# Altium schematic sheet 3xOuts.SchDoc
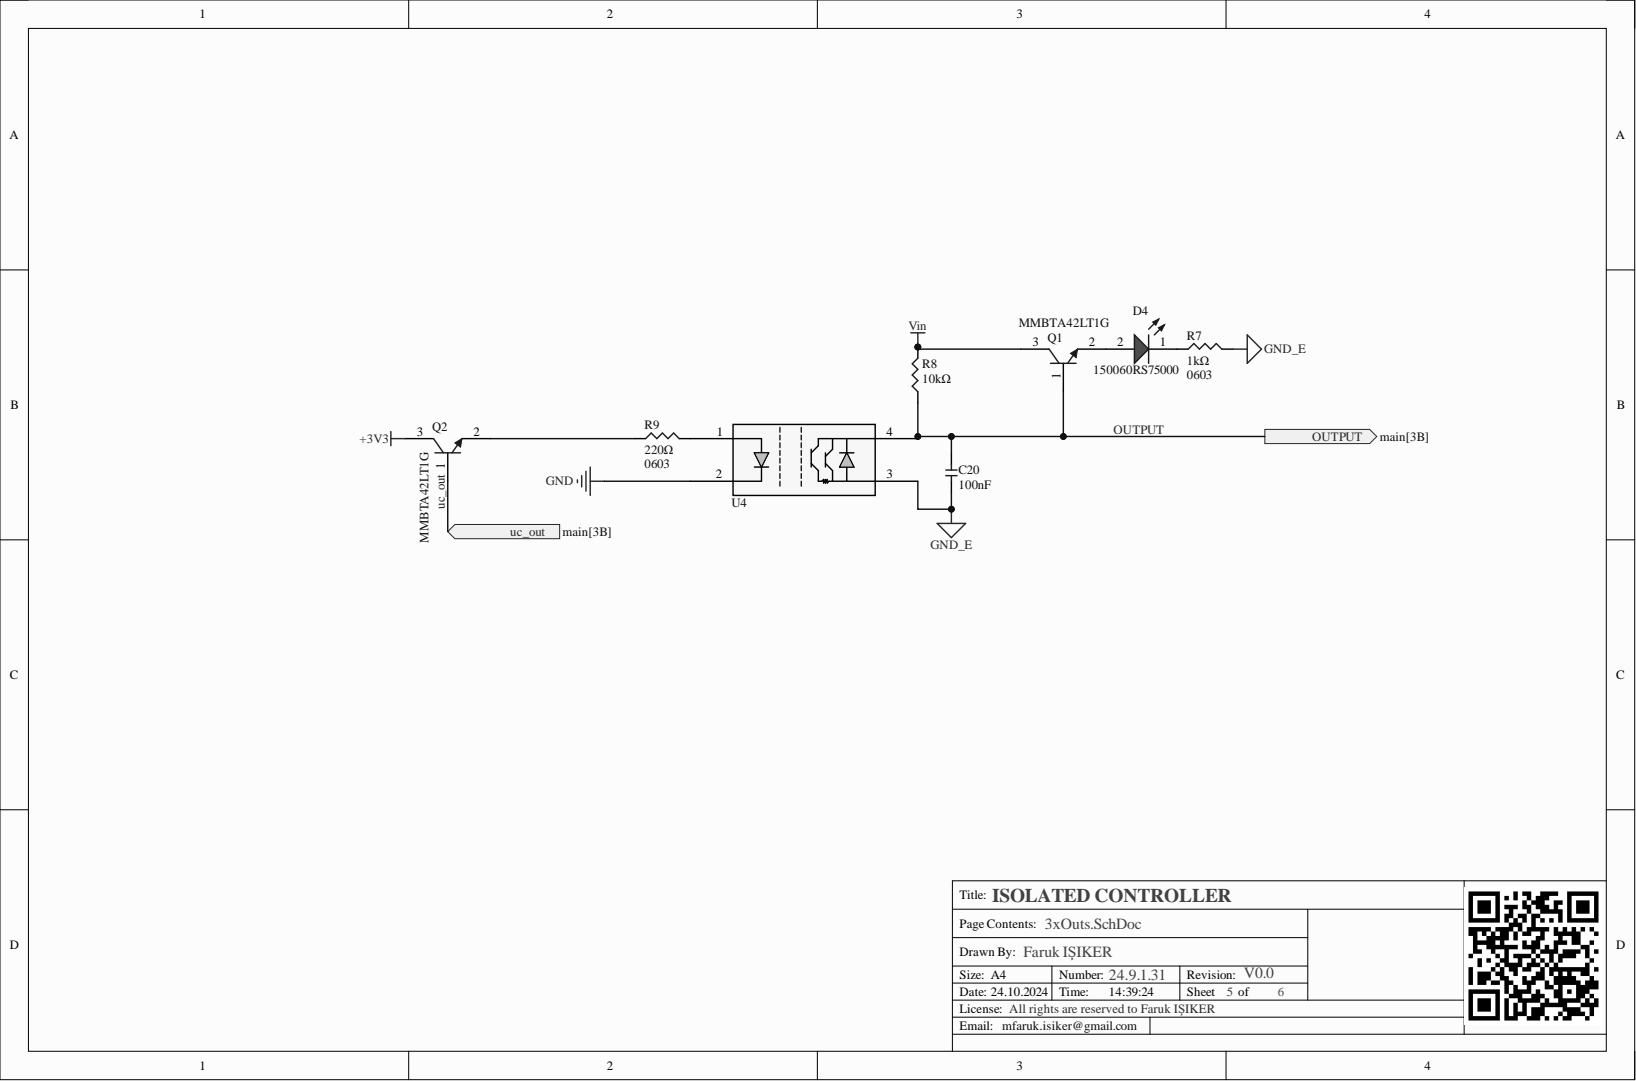
[diagram p0: 3xOuts.SchDoc]

## Parts
C20  value 100nF  [0603_cap]  (hidden comment: 0603_100nF)
D4  150060RS75000  Case Code (Imperial): 0603  [WL-SMCW_0603_150060xx75000]
Q1  MMBTA42LT1G  [ONSC-SOT-23-3-318-08_V]
Q2  MMBTA42LT1G  [ONSC-SOT-23-3-318-08_V]
R7  value 1kΩ  Package: 0603  [0603-smd]  (hidden comment: 0603_1K)
R8  value 10kΩ  [0603-smd]  (hidden comment: 0603_10K)
R9  0603_220R  value 220Ω  Package: 0603  [0603-smd]
U4  LTV-352T  [IC4_LTV-352T_LTO-M]  (value not drawn)

## Ports
OUTPUT, uc_out

## Nets
+3V3: Q2.3
GND: U4.2
GND_E: C20.1 R7.2 U4.3
Vin: Q1.3 R8.2
OUTPUT: C20.2 Q1.1 R8.1 U4.4 ; port OUTPUT
uc_out: Q2.1 ; port uc_out
NetD4_1: D4.1 R7.1
NetD4_2: D4.2 Q1.2
NetQ2_2: Q2.2 R9.1
NetR9_2: R9.2 U4.1

## Library data of the parts
C20 = 0603_100nF: Volt: V; Component Kind: Standard; Library Name: generic.SchLib; Package: 0603
D4 = CMP-1426-00001-3: NexarPartId: 29717776; Color: Red; Dominant Wavelength: 625nm; Forward Current: 100mA; Forward Voltage: 2V; Lens Style: Clear, Rectangular; Luminous Intensity: 250mcd; Max Junction Temperature (Tj): 95°C; Max Reverse Voltage (DC): 5V; Peak Wavelength: 630nm; Power Dissipation: 72mW; Test Current: 20mA; Viewing Angle: 140°; Case Code (Metric): 1608; Case/Package: 0603; Component Kind: Standard; Height: 700µm; Length: 1.6mm; Library Name: Altium Content Vault; Max Operating Temperature: 85°C; Min Operating Temperature: -40°C; Mount: Surface Mount; Packaging: Tape and Reel; REACH SVHC: Unknown; Reverse Voltage: 5V; RoHS: Compliant; Wavelength: 625nm; Width: 800µm
Q1 Q2 = CMP-1048-00662-1: High Voltage Transistor, NPN Silicon, 3-Pin SOT-23, Pb-Free, Tape and Reel; Manufacturer: On Semiconductor; fT Min (MHz): 50; IC Continuous (A): 0.5; Component Kind: Standard; ComponentLink1Description: Manufacturer URL; ComponentLink1URL: http://www.onsemi.com/; ComponentLink2Description: Datasheet; ComponentLink2URL: http://www.onsemi.cn/pub_link/Collateral/MMBTA42LT1-D.PDF; ComponentLink3Description: Package Specification; ComponentLink3URL: http://www.onsemi.cn/pub_link/Collateral/318-08.PDF; DatasheetVersion: Rev. 12; Library Name: Altium Content Vault; Mounting Technology: Surface Mount; PackageDescription: 3-Pin Small Outline Transistor, Body 2.92 x 1.3 mm, Pitch 0.95 mm; PackageReference: SOT-23-3-318-08; PackageVersion: Rev. AP, 11/2009; Packing: Tape and Reel; PartNumber: MMBTA42LT1G; Polarity: NPN; RoHS: TRUE
R7 = 0603_1K: Power: W; Component Kind: Standard; Library Name: generic.SchLib
R8 = 0603_10K: Power: W; Component Kind: Standard; Library Name: generic.SchLib; Package: 0603
R9 = 0603_220R: Power: W; Component Kind: Standard; Library Name: generic.SchLib
U4 = LTV-352T: LTV Series Single Channel 300V 3750 Vrms Transistor Output Optocoupler SMT DIP-4; Manufacturer: Vishay Lite-On; Mfr_Name: LITE-ON; Category: Optocouplers; Component Kind: Standard; ComponentLink1Description: https://datasheet.ciiva.com/11772/0900766b80de6aef-11772096.pdf?src-supplier=Rs; ComponentLink1URL: https://datasheet.ciiva.com/11772/0900766b80de6aef-11772096.pdf?src-supplier=Rs; ComponentLink2Description: https://datasheet.ciiva.com/2086/ltv-352t-2086258.pdf?src-supplier=Digikey; ComponentLink2URL: https://datasheet.ciiva.com/2086/ltv-352t-2086258.pdf?src-supplier=Digikey; ComponentLink3Description: https://datasheet.ciiva.com/19471/1260710-19471302.pdf?src-supplier=Jameco; ComponentLink3URL: https://datasheet.ciiva.com/19471/1260710-19471302.pdf?src-supplier=Jameco; ComponentLink4Description: https://datasheet.ciiva.com/15118/getdatasheetpartid-145582-15118811.pdf?src-supplier=Verical; ComponentLink4URL: https://datasheet.ciiva.com/15118/getdatasheetpartid-145582-15118811.pdf?src-supplier=Verical; ComponentLink5Description: https://datasheet.ciiva.com/2086/s-110-ltv-352t20140520-2086259.pdf?src-supplier=Digikey; ComponentLink5URL: https://datasheet.ciiva.com/2086/s-110-ltv-352t20140520-2086259.pdf?src-supplier=Digikey; ComponentLink6Description: https://datasheet.ciiva.com/2086/s-110-ltv-352t20140520-2086260.pdf?src-supplier=Digikey; ComponentLink6URL: https://datasheet.ciiva.com/2086/s-110-ltv-352t20140520-2086260.pdf?src-supplier=Digikey; Library Name: generic.SchLib; Manufacturer Part Number: LTV-352T; Pricing 1: 25=0,29, 50=0,278, 250=0,228, 500=0,2 (GBP); RefDes: RefDes; RoHS: Yes; Stock 1: 22730; Supplier 1: Rs; Supplier Part Number 1: 6912063P; Type: Type
